# Altium schematic sheet 07 LED_OSC.SchDoc
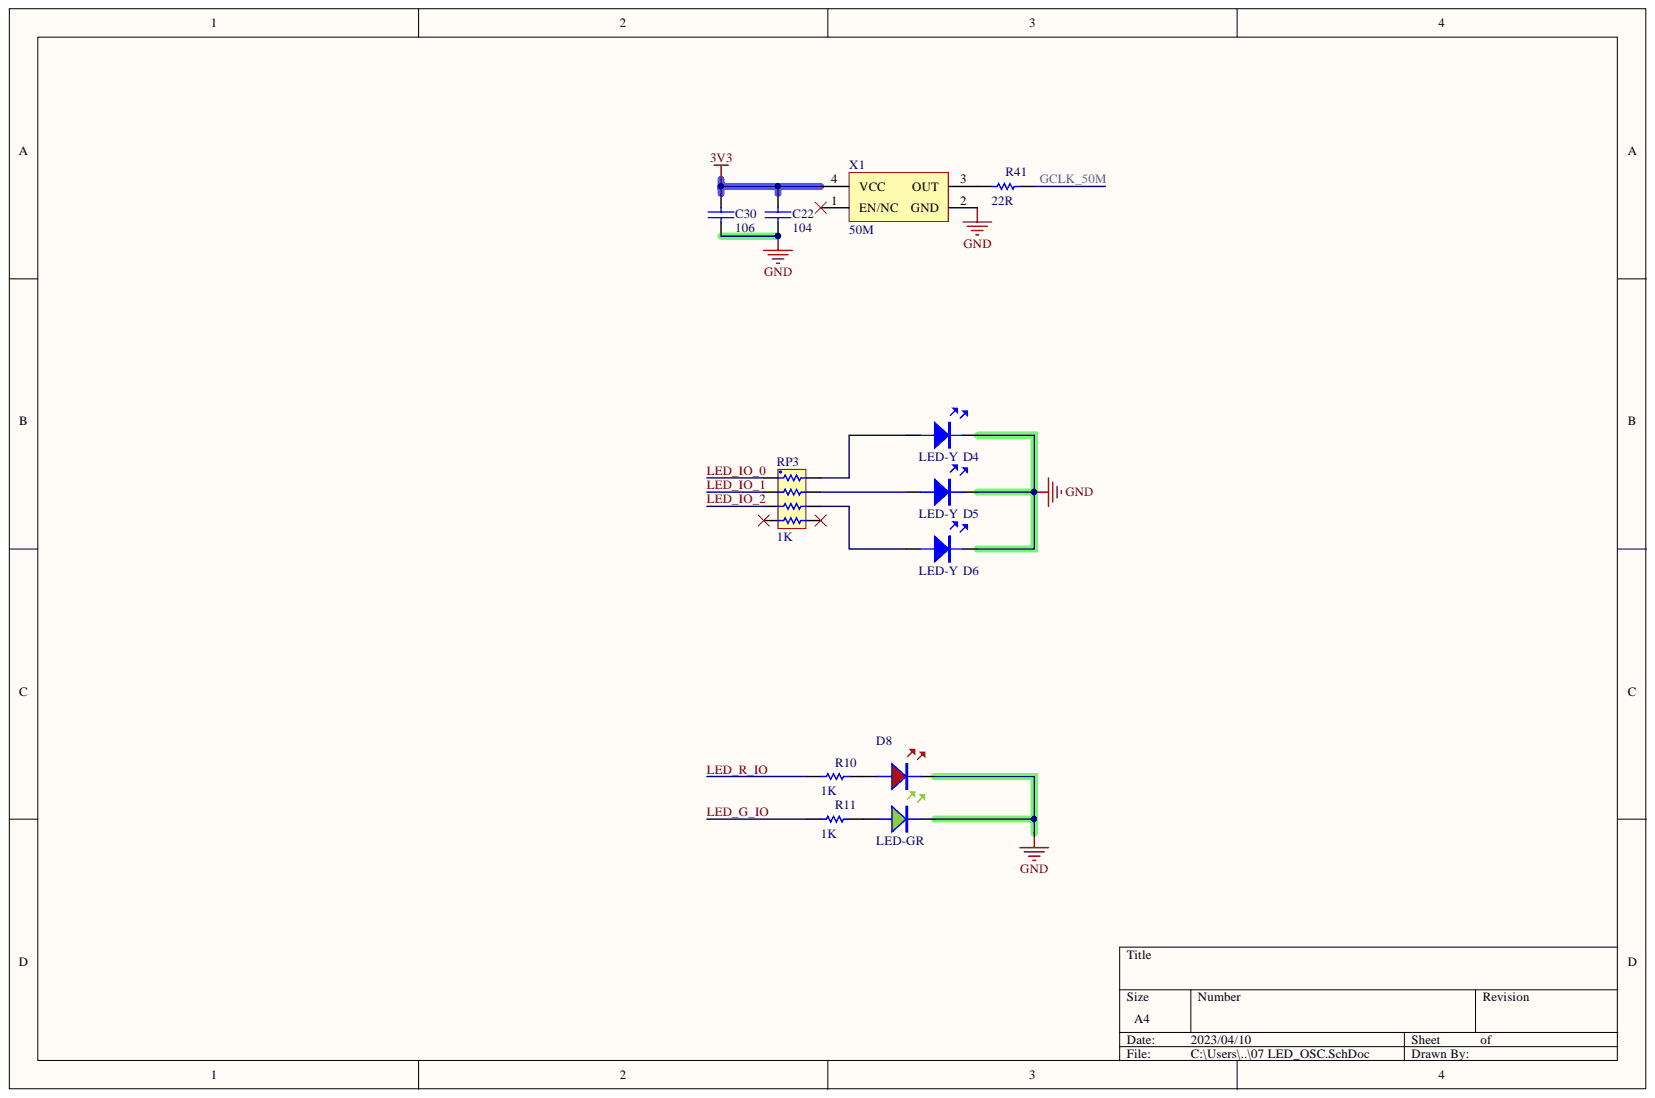
[diagram p0: 07 LED_OSC.SchDoc]

## Parts
C22  104  [0402C]
C30  106  [0402C]
D4  LED-Y  [LED-0603Y]
D5  LED-Y  [LED-0603Y]
D6  LED-Y  [LED-0603Y]
D8  LED-GR  [LED-DOUBLE]
R10  1K  [0402R]
R11  1K  [0402R]
R41  22R  [0402R]
RP3  1K  [贴片排阻]
X1  50M  [OSC 3225-4P]

## Nets
3V3: C22.2 C30.2 X1.4(VCC)
GND: C22.1 C30.1 D4.K D5.K D6.K D8.1 D8.2 X1.2(GND)
GCLK_50M: R41.1
LED_G_IO: R11.2
LED_IO_0: RP3.1
LED_IO_1: RP3.2
LED_IO_2: RP3.3
LED_R_IO: R10.2
NetD4_A: D4.A RP3.8
NetD5_A: D5.A RP3.7
NetD6_A: D6.A RP3.6
NetD8_3: D8.3 R11.1
NetD8_4: D8.4 R10.1
NetR41_2: R41.2 X1.3(OUT)

Not connected: RP3 4 5; X1 1(EN/NC)

## Library data of the parts
C22 C30 = CAP: 无极性电容; Component Kind: Standard; Library Name: 电容.IntLib
D4-D6 = LED: Component Kind: Standard; Library Name: LED.IntLib
D8 = LED-DOUBLE: Component Kind: Standard; Library Name: LED.IntLib
R10 R11 R41 = RES: Component Kind: Standard; Library Name: 电阻.IntLib
RP3 = SMT_RES_PACK8: Component Kind: Standard; Library Name: 电阻.IntLib
X1 = XTAL-ACT-4P: 4脚有源晶振; Component Kind: Standard; Library Name: 晶振.IntLib
